# Altium schematic sheet S7CAS-PLEIADES-CARTE-FILLE_P02_DAC.SchDoc
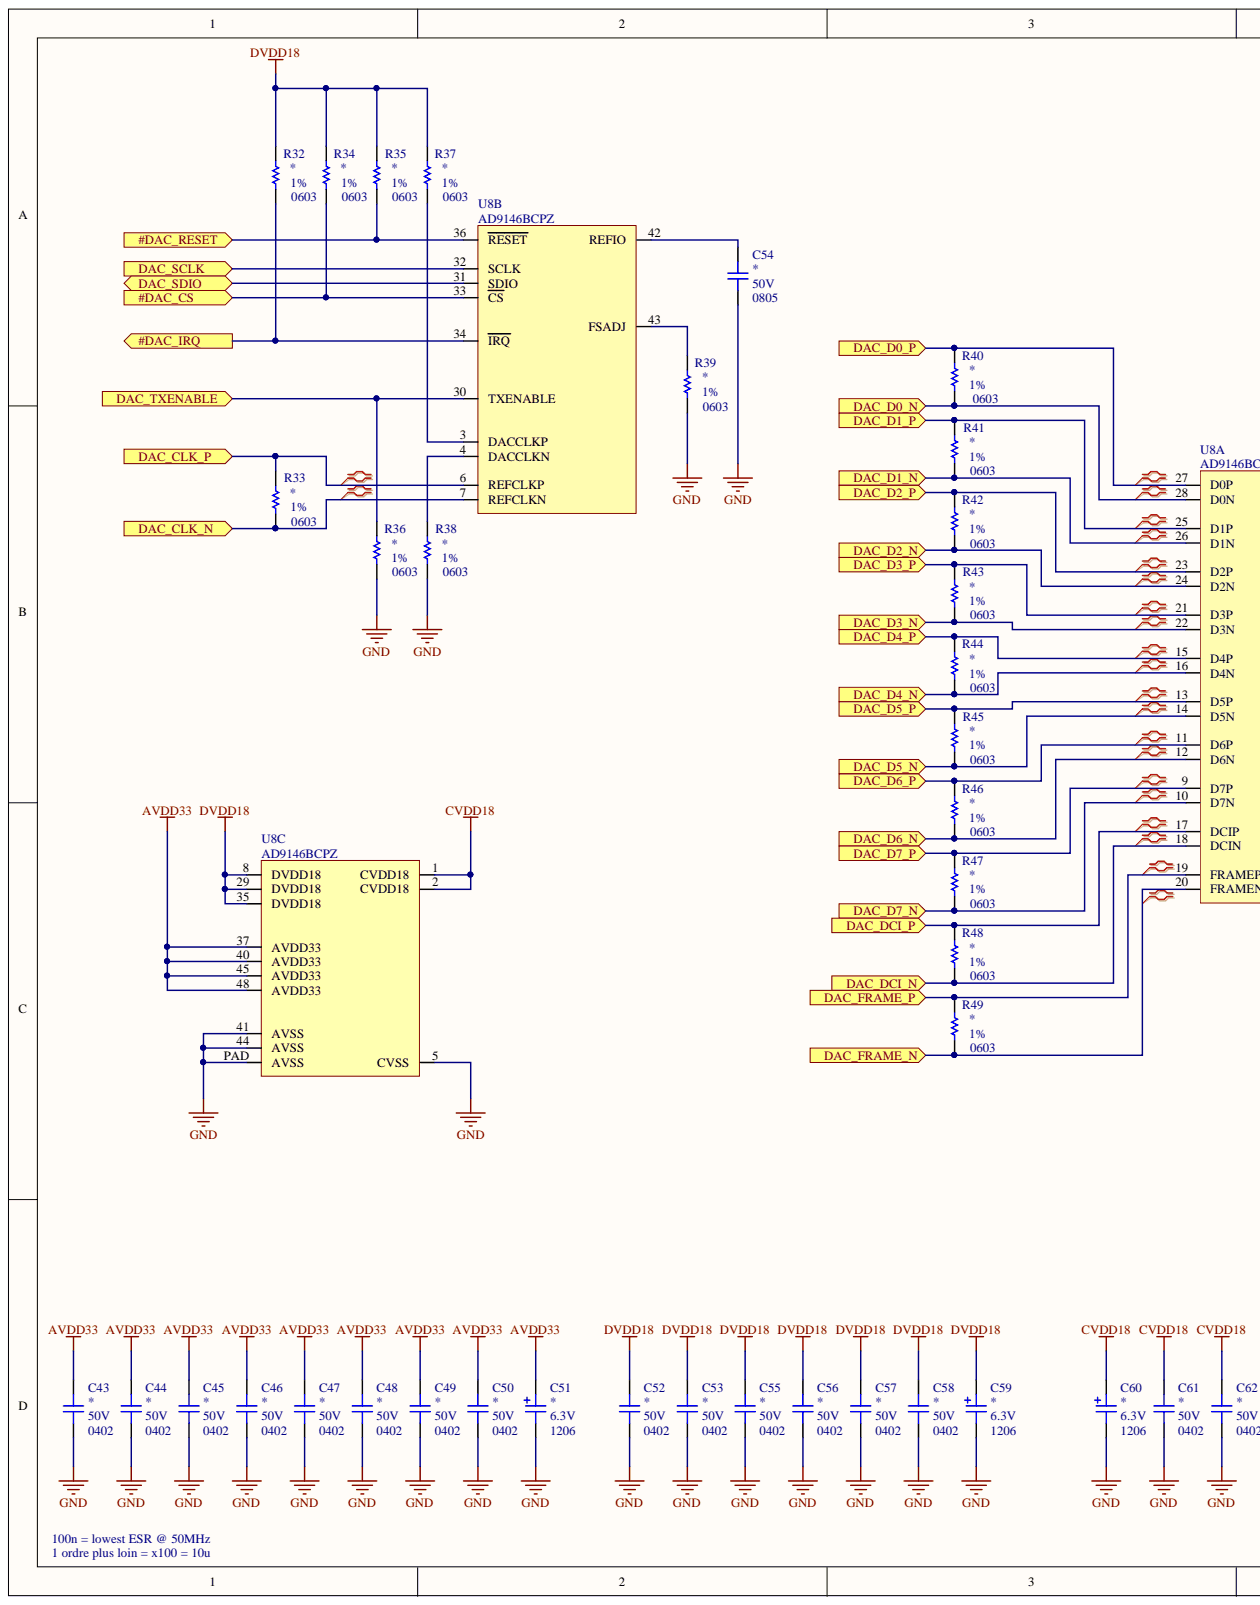
[diagram p0: S7CAS-PLEIADES-CARTE-FILLE_P02_DAC.SchDoc, left]
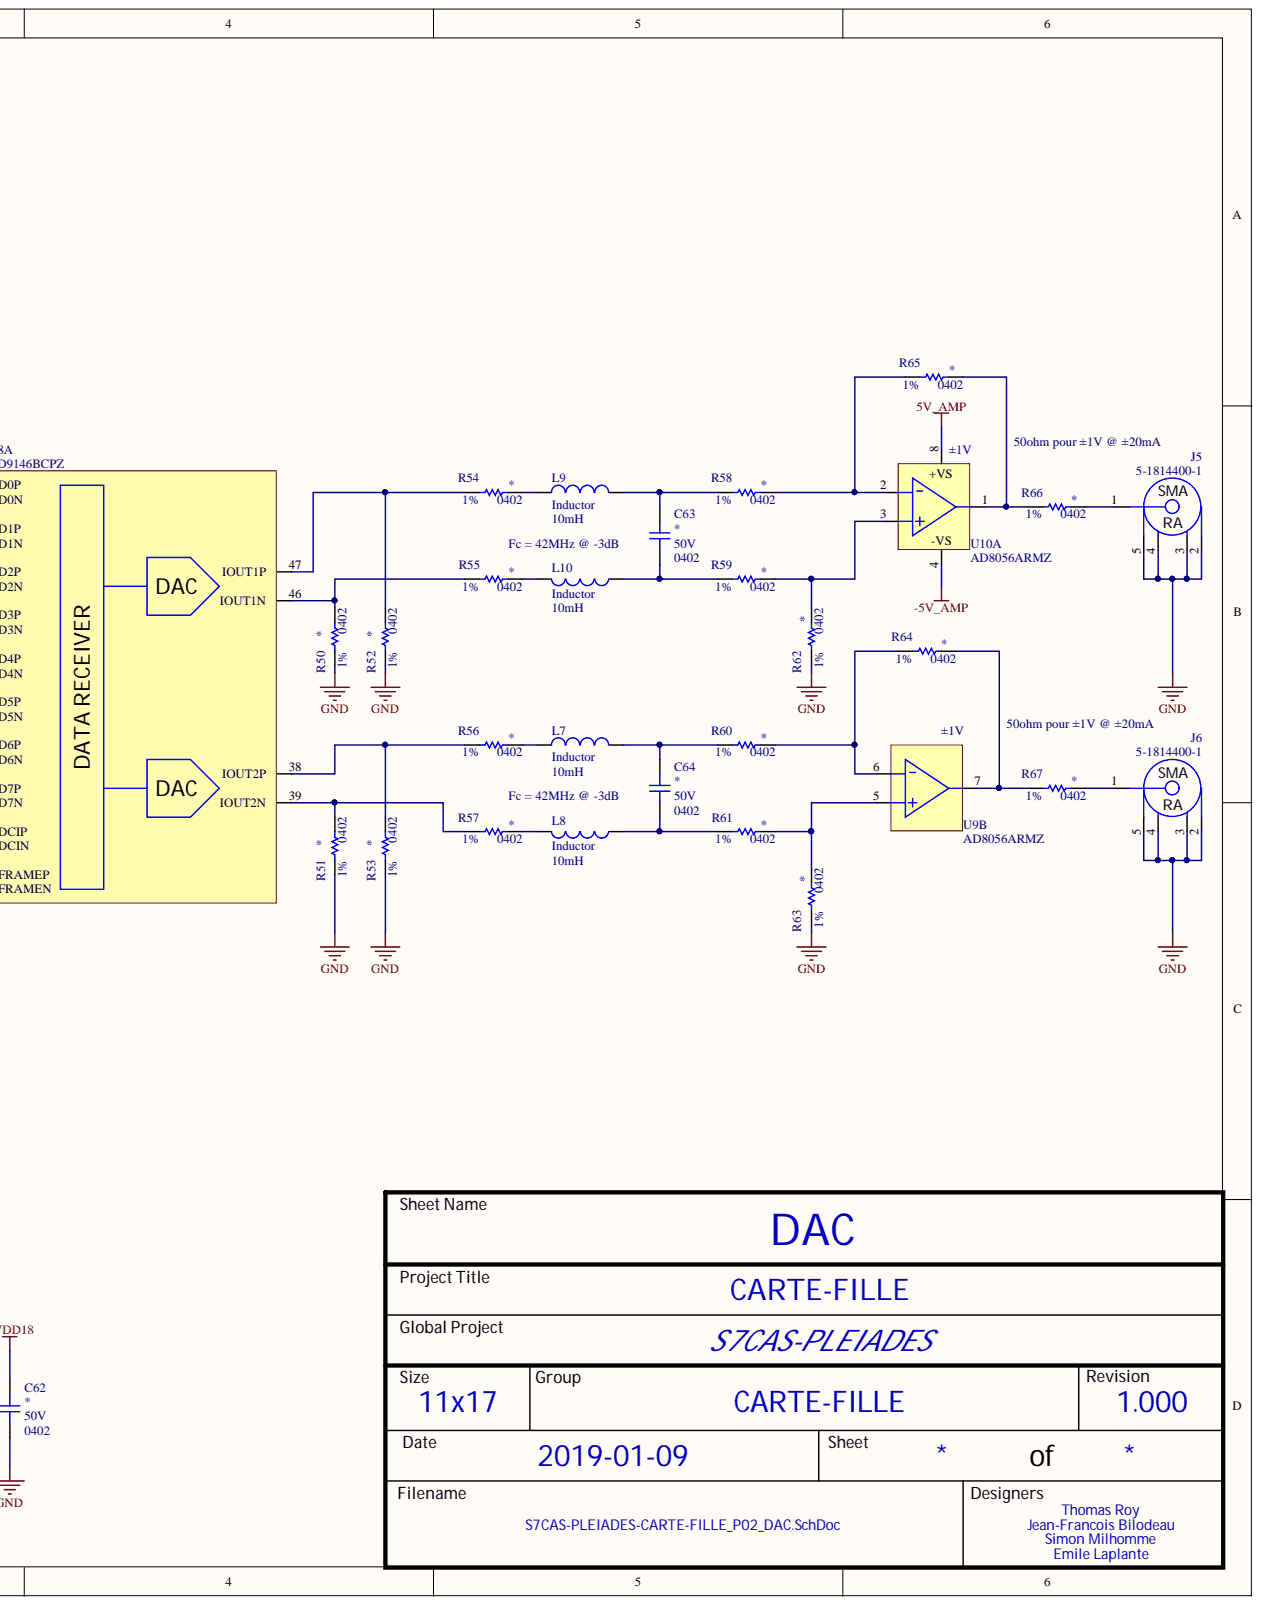
[diagram p1: S7CAS-PLEIADES-CARTE-FILLE_P02_DAC.SchDoc, right]

## Parts
C43  Package: 0402  Voltage: 50V  [CAP_0402]  (hidden comment: CAP - 0402)
C44  Package: 0402  Voltage: 50V  [CAP_0402]  (hidden comment: CAP - 0402)
C45  Package: 0402  Voltage: 50V  [CAP_0402]  (hidden comment: CAP - 0402)
C46  Package: 0402  Voltage: 50V  [CAP_0402]  (hidden comment: CAP - 0402)
C47  Package: 0402  Voltage: 50V  [CAP_0402]  (hidden comment: CAP - 0402)
C48  Package: 0402  Voltage: 50V  [CAP_0402]  (hidden comment: CAP - 0402)
C49  Package: 0402  Voltage: 50V  [CAP_0402]  (hidden comment: CAP - 0402)
C50  Package: 0402  Voltage: 50V  [CAP_0402]  (hidden comment: CAP - 0402)
C51  Package: 1206  Voltage: 6.3V  [CAP_TAN_1206(A)]  (hidden comment: CAP - TANTALUM - CASE A)
C52  Package: 0402  Voltage: 50V  [CAP_0402]  (hidden comment: CAP - 0402)
C53  Package: 0402  Voltage: 50V  [CAP_0402]  (hidden comment: CAP - 0402)
C54  Package: 0805  Voltage: 50V  [CAP_0805]  (hidden comment: CAP - 0805)
C55  Package: 0402  Voltage: 50V  [CAP_0402]  (hidden comment: CAP - 0402)
C56  Package: 0402  Voltage: 50V  [CAP_0402]  (hidden comment: CAP - 0402)
C57  Package: 0402  Voltage: 50V  [CAP_0402]  (hidden comment: CAP - 0402)
C58  Package: 0402  Voltage: 50V  [CAP_0402]  (hidden comment: CAP - 0402)
C59  Package: 1206  Voltage: 6.3V  [CAP_TAN_1206(A)]  (hidden comment: CAP - TANTALUM - CASE A)
C60  Package: 1206  Voltage: 6.3V  [CAP_TAN_1206(A)]  (hidden comment: CAP - TANTALUM - CASE A)
C61  Package: 0402  Voltage: 50V  [CAP_0402]  (hidden comment: CAP - 0402)
C62  Package: 0402  Voltage: 50V  [CAP_0402]  (hidden comment: CAP - 0402)
C63  Package: 0402  Voltage: 50V  [CAP_0402]  (hidden comment: CAP - 0402)
C64  Package: 0402  Voltage: 50V  [CAP_0402]  (hidden comment: CAP - 0402)
J5  5-1814400-1  [CONN_SMA_5-1814400-1]
J6  5-1814400-1  [CONN_SMA_5-1814400-1]
L7  Inductor  value 10mH  [0402-A]
L8  Inductor  value 10mH  [0402-A]
L9  Inductor  value 10mH  [0402-A]
L10  Inductor  value 10mH  [0402-A]
R32  Precision: 1%  [RES_0603]  (hidden comment: RES - 0603)
R33  Package: 0603  Precision: 1%  [RES_0603]  (hidden comment: RES - 0603)
R34  Package: 0603  Precision: 1%  [RES_0603]  (hidden comment: RES - 0603)
R35  Package: 0603  Precision: 1%  [RES_0603]  (hidden comment: RES - 0603)
R36  Precision: 1%  [RES_0603]  (hidden comment: RES - 0603)
R37  Package: 0603  Precision: 1%  [RES_0603]  (hidden comment: RES - 0603)
R38  Package: 0603  Precision: 1%  [RES_0603]  (hidden comment: RES - 0603)
R39  Package: 0603  Precision: 1%  [RES_0603]  (hidden comment: RES - 0603)
R40  Package: 0603  Precision: 1%  [RES_0603]  (hidden comment: RES - 0603)
R41  Package: 0603  Precision: 1%  [RES_0603]  (hidden comment: RES - 0603)
R42  Package: 0603  Precision: 1%  [RES_0603]  (hidden comment: RES - 0603)
R43  Package: 0603  Precision: 1%  [RES_0603]  (hidden comment: RES - 0603)
R44  Package: 0603  Precision: 1%  [RES_0603]  (hidden comment: RES - 0603)
R45  Package: 0603  Precision: 1%  [RES_0603]  (hidden comment: RES - 0603)
R46  Package: 0603  Precision: 1%  [RES_0603]  (hidden comment: RES - 0603)
R47  Package: 0603  Precision: 1%  [RES_0603]  (hidden comment: RES - 0603)
R48  Package: 0603  Precision: 1%  [RES_0603]  (hidden comment: RES - 0603)
R49  Package: 0603  Precision: 1%  [RES_0603]  (hidden comment: RES - 0603)
R50  Package: 0402  Precision: 1%  [RES_0402]  (hidden comment: RES - 0402)
R51  Package: 0402  Precision: 1%  [RES_0402]  (hidden comment: RES - 0402)
R52  Package: 0402  Precision: 1%  [RES_0402]  (hidden comment: RES - 0402)
R53  Package: 0402  Precision: 1%  [RES_0402]  (hidden comment: RES - 0402)
R54  Package: 0402  Precision: 1%  [RES_0402]  (hidden comment: RES - 0402)
R55  Package: 0402  Precision: 1%  [RES_0402]  (hidden comment: RES - 0402)
R56  Package: 0402  Precision: 1%  [RES_0402]  (hidden comment: RES - 0402)
R57  Package: 0402  Precision: 1%  [RES_0402]  (hidden comment: RES - 0402)
R58  Package: 0402  Precision: 1%  [RES_0402]  (hidden comment: RES - 0402)
R59  Package: 0402  Precision: 1%  [RES_0402]  (hidden comment: RES - 0402)
R60  Package: 0402  Precision: 1%  [RES_0402]  (hidden comment: RES - 0402)
R61  Package: 0402  Precision: 1%  [RES_0402]  (hidden comment: RES - 0402)
R62  Package: 0402  Precision: 1%  [RES_0402]  (hidden comment: RES - 0402)
R63  Package: 0402  Precision: 1%  [RES_0402]  (hidden comment: RES - 0402)
R64  Package: 0402  Precision: 1%  [RES_0402]  (hidden comment: RES - 0402)
R65  Package: 0402  Precision: 1%  [RES_0402]  (hidden comment: RES - 0402)
R66  Package: 0402  Precision: 1%  [RES_0402]  (hidden comment: RES - 0402)
R67  Package: 0402  Precision: 1%  [RES_0402]  (hidden comment: RES - 0402)
U8  AD9146BCPZ  [DAC_AD9146BCPZ]  (parts A,B,C)
U9  AD8056ARMZ  [OPAMP_AD8056ARMZ]  (part B)
U10  AD8056ARMZ  [OPAMP_AD8056ARMZ]  (part A)

## Ports
#DAC_CS, #DAC_IRQ, #DAC_RESET, DAC_CLK_N, DAC_CLK_P, DAC_D0_N, DAC_D0_P, DAC_D1_N, DAC_D1_P, DAC_D2_N, DAC_D2_P, DAC_D3_N, DAC_D3_P, DAC_D4_N, DAC_D4_P, DAC_D5_N, DAC_D5_P, DAC_D6_N, DAC_D6_P, DAC_D7_N, DAC_D7_P, DAC_DCI_N, DAC_DCI_P, DAC_FRAME_N, DAC_FRAME_P, DAC_SCLK, DAC_SDIO, DAC_TXENABLE

## Nets
5V_AMP: U10.8
-5V_AMP: U10.4
AVDD33: C43.2 C44.2 C45.2 C46.2 C47.2 C48.2 C49.2 C50.2 C51.2 U8.37(AVDD33) U8.40(AVDD33) U8.45(AVDD33) U8.48(AVDD33)
CVDD18: C60.2 C61.2 C62.2 U8.1(CVDD18) U8.2(CVDD18)
DVDD18: C52.2 C53.2 C55.2 C56.2 C57.2 C58.2 C59.2 R32.2 R34.2 R35.2 R37.2 U8.8(DVDD18) U8.29(DVDD18) U8.35(DVDD18)
GND: C43.1 C44.1 C45.1 C46.1 C47.1 C48.1 C49.1 C50.1 C51.1 C52.1 C53.1 C54.1 C55.1 C56.1 C57.1 C58.1 C59.1 C60.1 C61.1 C62.1 J5.2 J5.3 J5.4 J5.5 J6.2 J6.3 J6.4 J6.5 R36.1 R38.1 R39.1 R50.2 R51.2 R52.2 R53.2 R62.2 R63.2 U8.5(CVSS) U8.41(AVSS) U8.44(AVSS) U8.PAD(AVSS)
#DAC_CS: R34.1 U8.33(CS) ; port #DAC_CS
#DAC_IRQ: R32.1 U8.34(IRQ) ; port #DAC_IRQ
#DAC_RESET: R35.1 U8.36(RESET) ; port #DAC_RESET
DAC_CLK_N: R33.1 U8.7(REFCLKN) ; port DAC_CLK_N
DAC_CLK_P: R33.2 U8.6(REFCLKP) ; port DAC_CLK_P
DAC_D0_N: R40.1 U8.28(D0N) ; port DAC_D0_N
DAC_D0_P: R40.2 U8.27(D0P) ; port DAC_D0_P
DAC_D1_N: R41.1 U8.26(D1N) ; port DAC_D1_N
DAC_D1_P: R41.2 U8.25(D1P) ; port DAC_D1_P
DAC_D2_N: R42.1 U8.24(D2N) ; port DAC_D2_N
DAC_D2_P: R42.2 U8.23(D2P) ; port DAC_D2_P
DAC_D3_N: R43.1 U8.22(D3N) ; port DAC_D3_N
DAC_D3_P: R43.2 U8.21(D3P) ; port DAC_D3_P
DAC_D4_N: R44.1 U8.16(D4N) ; port DAC_D4_N
DAC_D4_P: R44.2 U8.15(D4P) ; port DAC_D4_P
DAC_D5_N: R45.1 U8.14(D5N) ; port DAC_D5_N
DAC_D5_P: R45.2 U8.13(D5P) ; port DAC_D5_P
DAC_D6_N: R46.1 U8.12(D6N) ; port DAC_D6_N
DAC_D6_P: R46.2 U8.11(D6P) ; port DAC_D6_P
DAC_D7_N: R47.1 U8.10(D7N) ; port DAC_D7_N
DAC_D7_P: R47.2 U8.9(D7P) ; port DAC_D7_P
DAC_DCI_N: R48.1 U8.18(DCIN) ; port DAC_DCI_N
DAC_DCI_P: R48.2 U8.17(DCIP) ; port DAC_DCI_P
DAC_FRAME_N: R49.1 U8.20(FRAMEN) ; port DAC_FRAME_N
DAC_FRAME_P: R49.2 U8.19(FRAMEP) ; port DAC_FRAME_P
DAC_SCLK: U8.32(SCLK) ; port DAC_SCLK
DAC_SDIO: U8.31(SDIO) ; port DAC_SDIO
DAC_TXENABLE: R36.2 U8.30(TXENABLE) ; port DAC_TXENABLE
NetC54_2: C54.2 U8.42(REFIO)
NetC63_1: C63.1 L10.1 R59.2
NetC63_2: C63.2 L9.2 R58.2
NetC64_1: C64.1 L8.1 R61.2
NetC64_2: C64.2 L7.2 R60.2
NetJ5_1: J5.1 R66.1
NetJ6_1: J6.1 R67.1
NetL7_1: L7.1 R56.1
NetL8_2: L8.2 R57.1
NetL9_1: L9.1 R54.1
NetL10_2: L10.2 R55.1
NetR37_1: R37.1 U8.3(DACCLKP)
NetR38_2: R38.2 U8.4(DACCLKN)
NetR39_2: R39.2 U8.43(FSADJ)
NetR50_1: R50.1 R55.2 U8.46(IOUT1N)
NetR51_1: R51.1 R57.2 U8.39(IOUT2N)
NetR52_1: R52.1 R54.2 U8.47(IOUT1P)
NetR53_1: R53.1 R56.2 U8.38(IOUT2P)
NetR58_1: R58.1 R65.2 U10.2
NetR59_1: R59.1 R62.1 U10.3
NetR60_1: R60.1 R64.2 U9.6
NetR61_1: R61.1 R63.1 U9.5
NetR64_1: R64.1 R67.2 U9.7
NetR65_1: R65.1 R66.2 U10.1

## Library data of the parts
C43-C50 C52 C53 C55-C58 C61-C64 = CAP - 0402: Precision: 10%; Component Kind: Standard; Description: CAP - 0402; Library Name: S7CAS_Lib2019_REV1_00.IntLib; CreatedBy: Jonathan Bouchard
C51 C59 C60 = CAP_TANT_1206(A): CAP - TANTALUM - 1206; Precision: 10%; Component Kind: Standard; Library Name: S7CAS_Lib2019_REV1_00.IntLib; CreatedBy: Jonathan Bouchard
C54 = CAP - 0805: Precision: 10%; Component Kind: Standard; Description: CAP - 0805; Library Name: S7CAS_Lib2019_REV1_00.IntLib; CreatedBy: Jonathan Bouchard
J5 J6 = CONN_SMA_5-1814400-1: CONN -  SMA JACK R/A 50 OHM - TH; Mfg: TE Connectivity AMP Connectors; Component Kind: Standard; HelpURL: http://www.te.com/commerce/DocumentDelivery/DDEController?Action=srchrtrv&DocNm=1814400&DocType=Customer+Drawing&DocLang=English; Library Name: S7CAS_Lib2019_REV1_00.IntLib; Mfg Part Number: 5-1814400-1; Package: TH-5; Supplier 1: Digikey; Supplier Part Number 1: A97593-ND; Temperature: -55°C ~ 85°C; CreatedBy: Jonathan Bouchard
L7-L10 = Inductor: Code_IEC: 1005; Code_JEITA: 0402; Component Kind: Standard; Description: Inductor; LatestRevisionDate: 29-May-2009; LatestRevisionNote: IPC-7351 Footprint Added.; Library Name: Miscellaneous Devices.IntLib; PackageDescription: Chip Inductor; PackageReference: 0402-A; Published: 8-Jun-2000; Signal Integrity: Inductor; Simulation: INDUCTOR; Publisher: Altium Limited
R50-R67 = RES - 0402: Power: 1/16W; Voltage: 50V; Component Kind: Standard; Description: RES - 0402; Library Name: S7CAS_Lib2019_REV1_00.IntLib; CreatedBy: Jonathan Bouchard
U8 = DAC_AD9146BCPZ: DAC - 16BIT SRL HIGH SPEED - QFN-48; Mfg: Analog Devices Inc.; Voltage: 3.13 V ~ 3.47 V / 1.71 V ~ 1.89 V; Component Kind: Standard; HelpURL: http://www.analog.com/media/en/technical-documentation/data-sheets/AD9146.PDF; Library Name: S7CAS_Lib2019_REV1_00.IntLib; Mfg Part Number: AD9146BCPZ; Package: QFN-48; Precision: 16 Bit; Supplier 1: Digikey; Supplier Part Number 1: AD9146BCPZ-ND; Temperature: -40°C ~ 85°C; CreatedBy: Jonathan Bouchard
U9 U10 = OPAMP_AD8056ARMZ: OPAMP - VFB 300MHZ - 8MSOP; Current: 60mA; Mfg: Analog Devices; Voltage: 8 V ~ 12 V, ±4 V ~ 6 V; Component Kind: Standard; HelpURL: http://www.analog.com/media/en/technical-documentation/data-sheets/AD8055_8056.pdf; Library Name: S7CAS_Lib2019_REV1_00.IntLib; Mfg Part Number: AD8056ARMZ; Package: MSOP-8; Supplier 1: Digikey; Supplier Part Number 1: AD8056ARMZ-REEL7CT-ND; Temperature: -40°C ~ 125°C; CreatedBy: Jonathan Bouchard
R32-R49 = RES - 0603: Component Kind: Standard; Description: RES - 0603; Library Name: S7CAS_Lib2019_REV1_00.IntLib; CreatedBy: Jonathan Bouchard
  R32 R36: Package: 0603
